# Altium schematic sheet Fanet.SchDoc
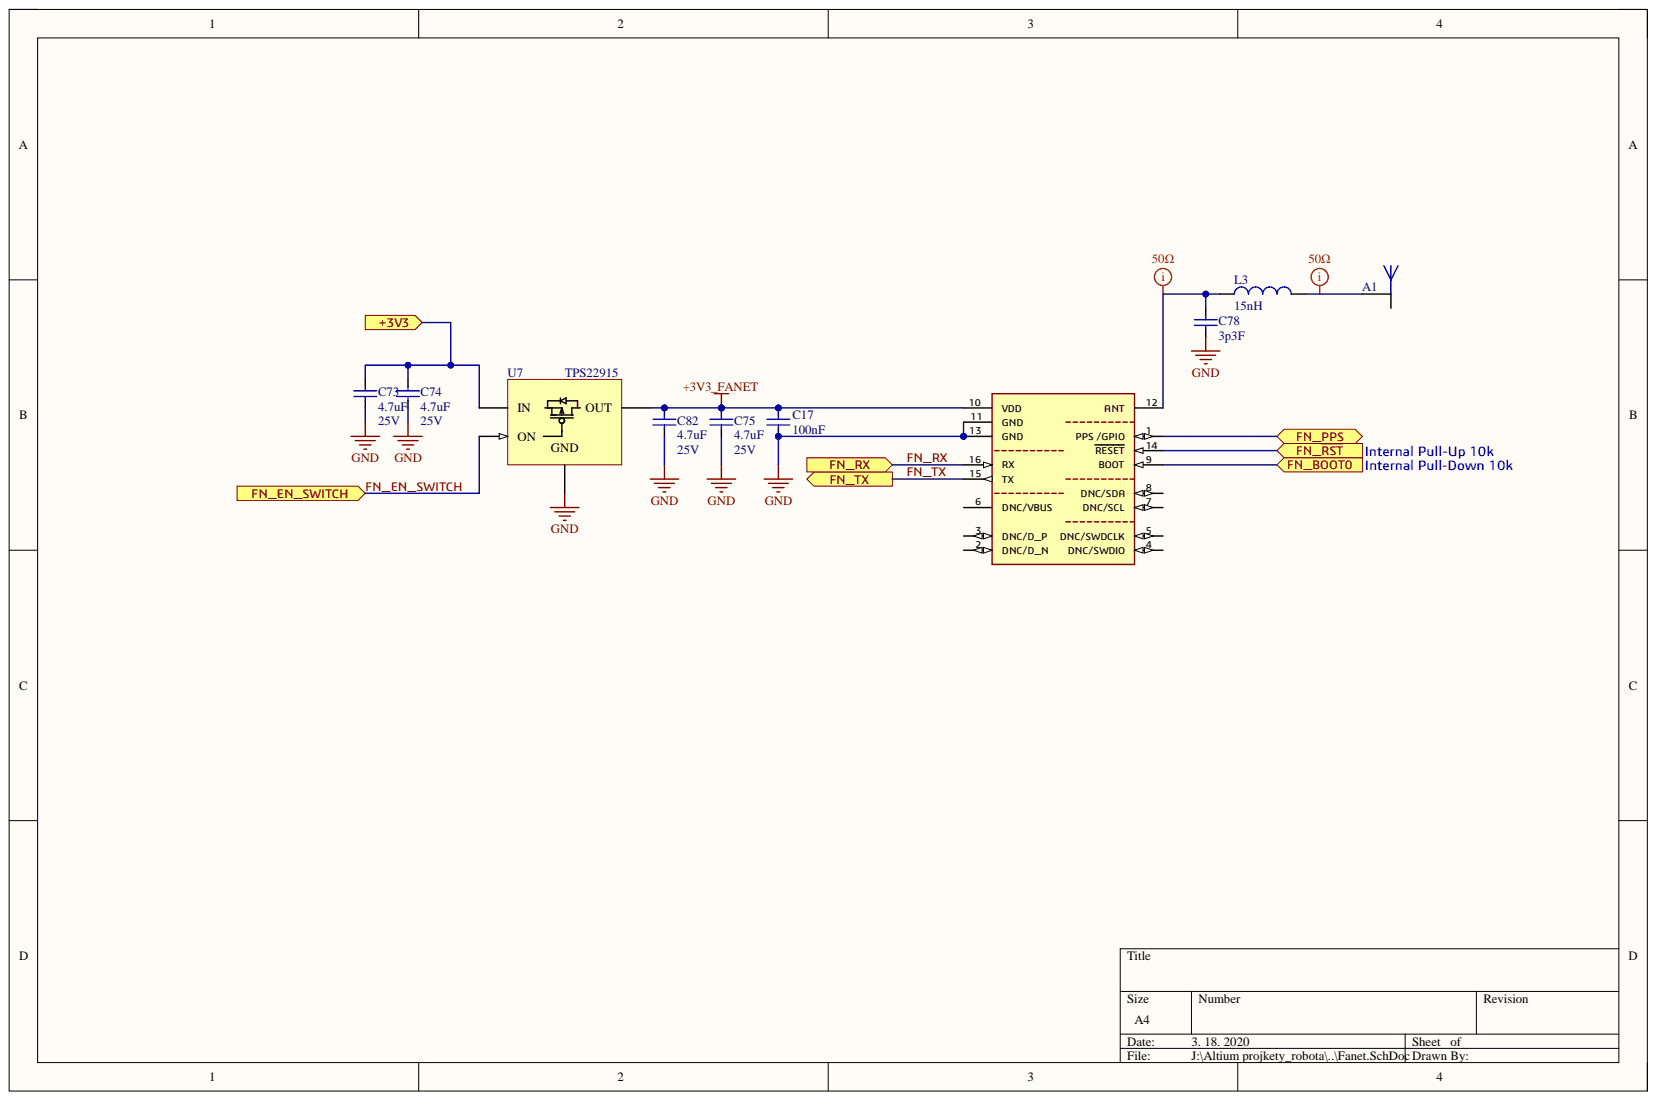
[diagram p0: Fanet.SchDoc]

## Parts
A1  Antenna  [W3329 PCB]  (value not drawn)
C17  100nF  [CAPACITOR_0402_(1005)]
C73  4.7uF  Voltage: 25V  [CAPACITOR_0603_(1608)]
C74  4.7uF  Voltage: 25V  [CAPACITOR_0603_(1608)]
C75  4.7uF  Voltage: 25V  [CAPACITOR_0603_(1608)]
C78  3p3F  [CAPACITOR_0402_(1005)]
C82  4.7uF  Voltage: 25V  [CAPACITOR_0603_(1608)]
L3  15nH  [INDUCTOR_0402_(1005)]
U4  Fanet+ Module  [Fanet]  (value not drawn)
U7  TPS22915  [DSBGA4_0.4mmpitch]

## Ports
+3V3, FN_BOOT0, FN_EN_SWITCH, FN_PPS, FN_RX, FN_TX, FN_~{RST}

## Nets
+3V3_FANET: C17.2 C75.1 C82.1 U4.10(VDD) U7.A1(OUT)
GND: C17.1 C73.2 C74.2 C75.2 C78.1 C82.2 U4.11(GND) U4.13(GND) U7.B1
+3V3: C73.1 C74.1 U7.A2(IN) ; port +3V3
FN_BOOT0: U4.9(BOOT) ; port FN_BOOT0
FN_EN_SWITCH: U7.B2(ON) ; port FN_EN_SWITCH
FN_PPS: U4.1(PPS_/GPIO) ; port FN_PPS
FN_~{RST}: U4.14(RESET) ; port FN_~{RST}
FN_RX: U4.16(RX) ; port FN_RX
FN_TX: U4.15(TX) ; port FN_TX
NetA1_1: A1.1 L3.2
NetC78_2: C78.2 L3.1 U4.12(ANT)

Not connected: A1 2; U4 2(DNC/D_N) 3(DNC/D_P) 4(DNC/SWDIO) 5(DNC/SWDCLK) 6(DNC/VBUS) 7(DNC/SCL) 8(DNC/SDA)

## Library data of the parts
C17 C78 = CAPACITOR: ESR: 0.28R; Voltage Rating: 10V; Component Kind: Standard; Description: CAPACITOR; Library Name: RLCFB.SchLib
  C17: Capacitance: 100nF
  C78: Capacitance: 3p3F
C73-C75 C82 = CAPACITOR: Manufacturer 1: Murata; Manufacturer Part Number 1: GRM188R61E475KE11D; Dielectric: X5R; ESR: 0.28R; Material: Ceramic; Tolerance: 10%; Capacitance: 4.7uF; Case Code (Imperial): 0603; Case Code (Metric): 1608; Case/Package: 0603; Component Kind: Standard; ComponentLink1Description: https://datasheet.ciiva.com/46444/1769893-46444982.pdf?src-supplier=Element14; ComponentLink1URL: https://datasheet.ciiva.com/46444/1769893-46444982.pdf?src-supplier=Element14; ComponentLink2Description: https://datasheet.ciiva.com/46444/1769893-46444983.pdf?src-supplier=Element14; ComponentLink2URL: https://datasheet.ciiva.com/46444/1769893-46444983.pdf?src-supplier=Element14; ComponentLink3Description: https://datasheet.ciiva.com/14891/partnumbering-e-01-14891102.pdf?src-supplier=Digi-Key; ComponentLink3URL: https://datasheet.ciiva.com/14891/partnumbering-e-01-14891102.pdf?src-supplier=Digi-Key; ComponentLink4Description: https://datasheet.ciiva.com/14891/partnumbering-e-01-14891103.pdf?src-supplier=Digi-Key; ComponentLink4URL: https://datasheet.ciiva.com/14891/partnumbering-e-01-14891103.pdf?src-supplier=Digi-Key; Depth: 0.8mm; Description: CAPACITOR; Height: 0.8mm; Lead Free: Lead Free; Length: 1.6mm; Max Operating Temperature: 85°C; Min Operating Temperature: -55°C; Mount: Surface Mount; Package Quantity: 4000; Packaging: Cut Tape; Pricing 1: 10=0,35700, 100=100,00000, 500=0,15900, 1000=0,12800, 2000=0,10200 (CHF); REACH SVHC: No SVHC; RoHS: Yes; Stock 1: 95119; Supplier 1: Farnell; Supplier Part Number 1: 2426959; Thickness: 0.031inch; Voltage Rating: 25V; Voltage Rating (DC): 25V; Width: 0.031inch; Library Name: RLCFB.SchLib
L3 = INDUCTOR: INDUCTOR_High_Frequency; DC Current Rating: 2mA; DC Resistance Max: 1R; Component Kind: Standard; Inductance: 15nH; Library Name: RLCFB.SchLib
U4 = Fanet+ Module: SKYTRAXX FANET+ Module; DeviceSetName: FANET_MODULE; GateName_1: G$1; SymbolName_1: FANET_INT; Component Kind: Standard; ComponentLink1Description: Datasheet; ComponentLink1URL: https://github.com/3s1d/fanet-stm32/blob/master/fanet_module.pdf; Library Name: BB1_SCH_Library.SchLib; LibraryName: fanet (1); Package: FANET_INTERNAL
U7 = TPS22915: TPS22915  Power Switch ICs - Power Distribution 5.5V, 2A, 38mOhm Load Switch   tR=64 µs  QUICK OUTPUT DISCHARGE=Yes; Manufacturer 1: Texas Instruments; Manufacturer Part Number 1: TPS22915BYFPR; Interface: On/Off; Number of Channels: 1; Output Configuration: High Side; Quiescent Current: 7.7uA; Component Kind: Standard; ComponentLink1Description: http://www.ti.com/lit/ds/symlink/tps22915.pdf?src-supplier=Digi-Key; ComponentLink1URL: http://www.ti.com/lit/ds/symlink/tps22915.pdf?src-supplier=Digi-Key; ComponentLink2Description: http://www.ti.com/general/docs/lit/getliterature.tsp?genericPartNumber=TPS22915&&fileType=pdf&src-supplier=Avnet; ComponentLink2URL: http://www.ti.com/general/docs/lit/getliterature.tsp?genericPartNumber=TPS22915&&fileType=pdf&src-supplier=Avnet; ComponentLink3Description: http://www.ti.com/lit/gpn/tps22915?src-supplier=Mouser; ComponentLink3URL: http://www.ti.com/lit/gpn/tps22915?src-supplier=Mouser; Lead Free: Lead Free; Library Name: BB1_SCH_Library.SchLib; Max Operating Temperature: 85°C; Max Output Current: 2A; Min Operating Temperature: -40°C; Mount: Surface Mount; Number of Outputs: 1; Number of Pins: 4; Packaging: Tape and Reel; Pricing 1: 1=0,42000, 10=0,35000, 100=0,21300, 1000=0,16400, 3000=0,14000, 9000=0,13000 (USD); RoHS: Yes; Stock 1: 3444; Supplier 1: Mouser; Supplier Part Number 1: 595-TPS22915BYFPR
A1 = Antenna: Component Kind: Standard; Library Name: BB1_SCH_Library.SchLib
